# Altium schematic sheet Reference_Design_STM32WL_4L_IPD_ST_HP.SchDoc(Reference_Design_STM32WL_4L_IPD_ST_HP)
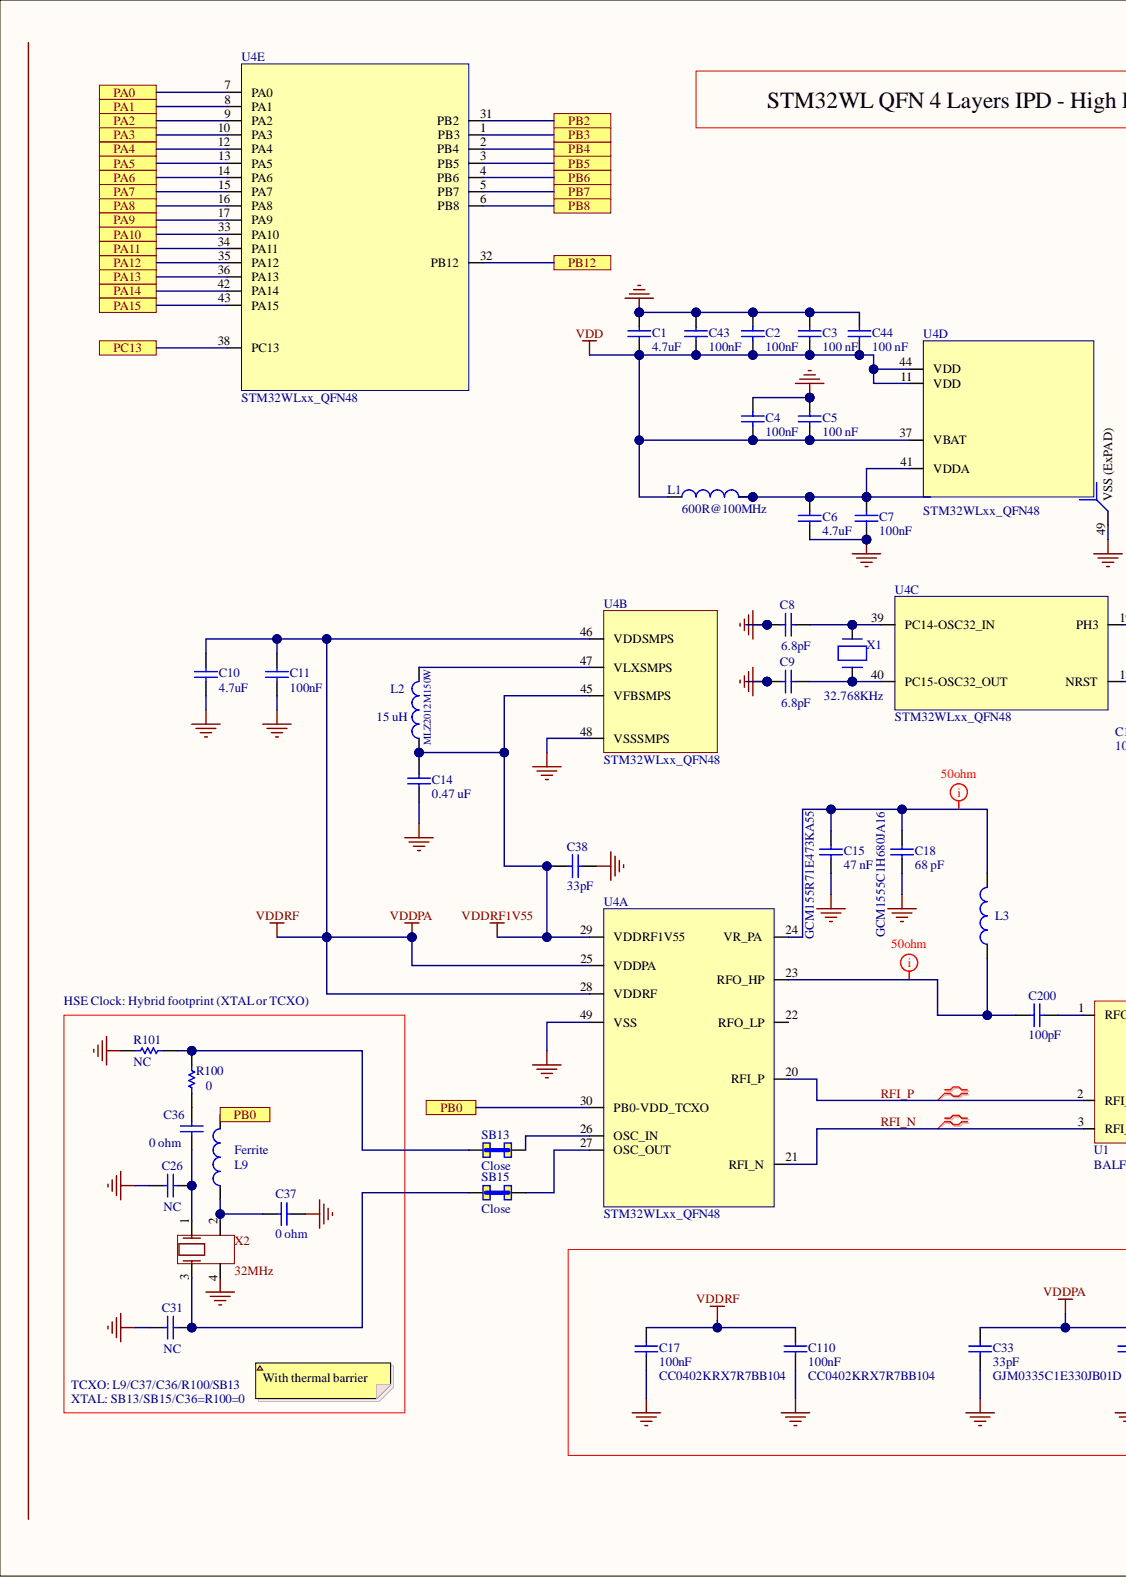
[diagram p0: Reference_Design_STM32WL_4L_IPD_ST_HP.SchDoc, left]
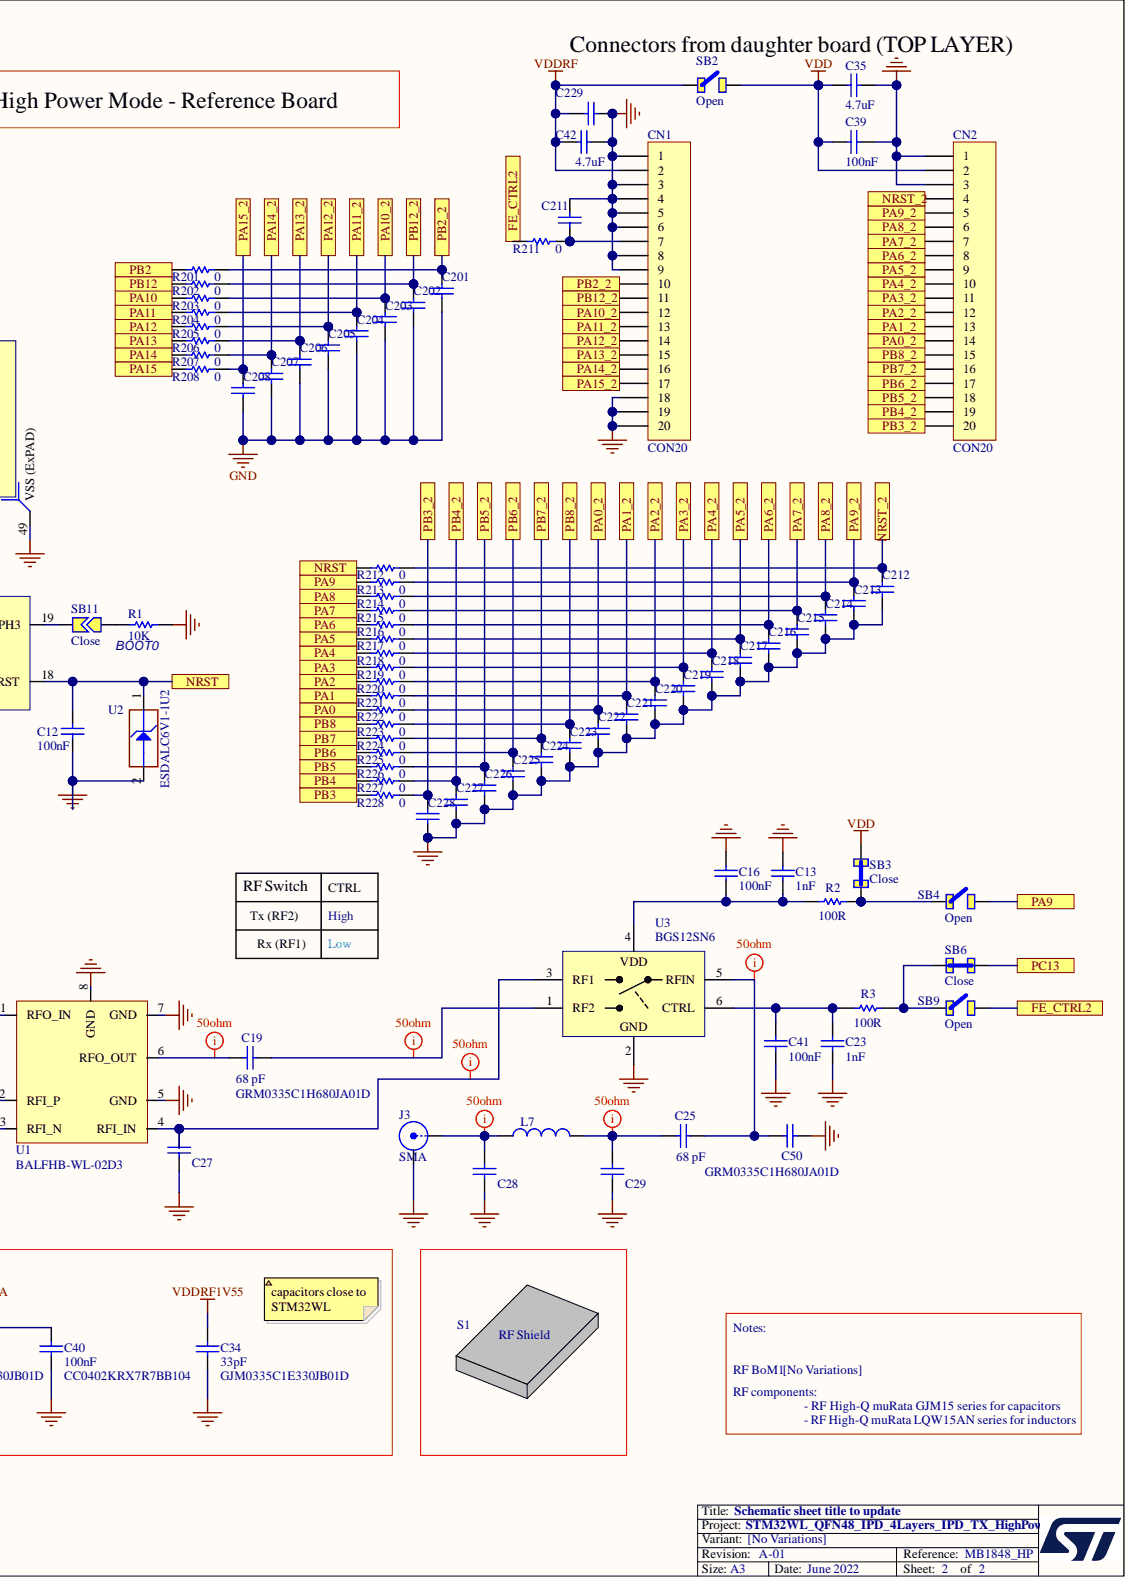
[diagram p1: Reference_Design_STM32WL_4L_IPD_ST_HP.SchDoc, right]

## Parts
C1  value 4.7uF  [CAPC1608X09N]
C2  value 100nF  [CAPC1005X06N]
C3  value 100 nF  [0201]
C4  value 100nF  [CAPC1005X06N]
C5  value 100 nF  [0201]
C6  value 4.7uF  [CAPC1608X09N]
C7  value 100nF  [CAPC1005X06N]
C8  value 6.8pF  [0402]  (hidden comment: Capacitor)
C9  value 6.8pF  [0402]  (hidden comment: Capacitor)
C10  value 4.7uF  [CAPC1608X09N]
C11  value 100nF  [CAPC1005X06N]
C12  value 100nF  [0402]
C13  value 1nF  [0201]  (hidden comment: MCD-00198)
C14  value 0.47 uF  [CAPC1608X09N]
C15  value 47 nF  Manufacturer_Part_Number: GCM155R71E473KA55  [0402]
C16  value 100nF  [0201]  (hidden comment: MCD-00102)
C17  value 100nF  Manufacturer_Part_Number: CC0402KRX7R7BB104  [CAPC1005X06N]
C18  value 68 pF  Manufacturer_Part_Number: GCM1555C1H680JA16  [0402]
C19  value 68 pF  Manufacturer_Part_Number: GRM0335C1H680JA01D  [rlc_201_smd]  (hidden comment: RFCapacitor_201)
C23  value 1nF  [0201]  (hidden comment: MCD-00198)
C25  value 68 pF  [rlc_201_smd]  (hidden comment: RFCapacitor_201)
C26  value NC  [0402]  (hidden comment: Capacitor)
C27  NC  [0201]  (value not drawn)
C28  RFCapacitor_201  [rlc_201_smd]  (value not drawn)
C29  RFCapacitor_201  [rlc_201_smd]  (value not drawn)
C31  value NC  [0402]  (hidden comment: Capacitor)
C33  value 33pF  Manufacturer_Part_Number: GJM0335C1E330JB01D  [0201]
C34  value 33pF  Manufacturer_Part_Number: GJM0335C1E330JB01D  [0201]
C35  value 4.7uF  [CAPC1608X09N]
C36  value 0 ohm  [0402]
C37  value 0 ohm  [0402]
C38  value 33pF  [0201]
C39  value 100nF  [CAPC1005X06N]
C40  value 100nF  Manufacturer_Part_Number: CC0402KRX7R7BB104  [CAPC1005X06N]
C41  value 100nF  [0201]  (hidden comment: MCD-00102)
C42  value 4.7uF  [CAPC1608X09N]
C43  value 100nF  [CAPC1005X06N]
C44  value 100 nF  [0201]
C50  RFCapacitor_201  [rlc_201_smd]  (value not drawn)
C110  value 100nF  Manufacturer_Part_Number: CC0402KRX7R7BB104  [CAPC1005X06N]
C200  value 100pF  [0201]  (hidden comment: MCD-00198)
C201  RFCapacitor_201  [rlc_201_smd]  (value not drawn)
C202  RFCapacitor_201  [rlc_201_smd]  (value not drawn)
C203  RFCapacitor_201  [rlc_201_smd]  (value not drawn)
C204  RFCapacitor_201  [rlc_201_smd]  (value not drawn)
C205  RFCapacitor_201  [rlc_201_smd]  (value not drawn)
C206  RFCapacitor_201  [rlc_201_smd]  (value not drawn)
C207  RFCapacitor_201  [rlc_201_smd]  (value not drawn)
C208  RFCapacitor_201  [rlc_201_smd]  (value not drawn)
C211  RFCapacitor_201  [rlc_201_smd]  (value not drawn)
C212  RFCapacitor_201  [rlc_201_smd]  (value not drawn)
C213  RFCapacitor_201  [rlc_201_smd]  (value not drawn)
C214  RFCapacitor_201  [rlc_201_smd]  (value not drawn)
C215  RFCapacitor_201  [rlc_201_smd]  (value not drawn)
C216  RFCapacitor_201  [rlc_201_smd]  (value not drawn)
C217  RFCapacitor_201  [rlc_201_smd]  (value not drawn)
C218  RFCapacitor_201  [rlc_201_smd]  (value not drawn)
C219  RFCapacitor_201  [rlc_201_smd]  (value not drawn)
C220  RFCapacitor_201  [rlc_201_smd]  (value not drawn)
C221  RFCapacitor_201  [rlc_201_smd]  (value not drawn)
C222  RFCapacitor_201  [rlc_201_smd]  (value not drawn)
C223  RFCapacitor_201  [rlc_201_smd]  (value not drawn)
C224  RFCapacitor_201  [rlc_201_smd]  (value not drawn)
C225  RFCapacitor_201  [rlc_201_smd]  (value not drawn)
C226  RFCapacitor_201  [rlc_201_smd]  (value not drawn)
C227  RFCapacitor_201  [rlc_201_smd]  (value not drawn)
C228  RFCapacitor_201  [rlc_201_smd]  (value not drawn)
C229  RFCapacitor_201  [rlc_201_smd]  (value not drawn)
CN1  CON20  [CO_SAMTEC_TSW-120-07-x-S]
CN2  CON20  [CO_SAMTEC_TSW-120-07-x-S]
J3  SMA  [SMA_AMPHENOL_50ohms_Edge_PCB]
L1  value 600R@100MHz  [INDC1608X95N]
L2  value 15 uH  Manufacturer_Part_Number: MLZ2012M150W  [INDC2012X13N]
L3  MCD-01330  [IND_MURATA_LQW15A]  (value not drawn)
L7  Ferrite  [rlc_201_smd]  (value not drawn)
L9  Ferrite  [IND_MURATA_LQW15A]
R1  value 10K  [RESC1608X05N]
R2  value 100R  [0201]  (hidden comment: MCD-00119)
R3  value 100R  [0201]  (hidden comment: MCD-00119)
R100  value 0  [R0402]  (hidden comment: Resistor)
R101  value NC  [R0402]  (hidden comment: Resistor)
R201  value 0  [0201]  (hidden comment: MCD-00119)
R202  value 0  [0201]  (hidden comment: MCD-00119)
R203  value 0  [0201]  (hidden comment: MCD-00119)
R204  value 0  [0201]  (hidden comment: MCD-00119)
R205  value 0  [0201]  (hidden comment: MCD-00119)
R206  value 0  [0201]  (hidden comment: MCD-00119)
R207  value 0  [0201]  (hidden comment: MCD-00119)
R208  value 0  [0201]  (hidden comment: MCD-00119)
R211  value 0  [0201]  (hidden comment: MCD-00119)
R212  value 0  [0201]  (hidden comment: MCD-00119)
R213  value 0  [0201]  (hidden comment: MCD-00119)
R214  value 0  [0201]  (hidden comment: MCD-00119)
R215  value 0  [0201]  (hidden comment: MCD-00119)
R216  value 0  [0201]  (hidden comment: MCD-00119)
R217  value 0  [0201]  (hidden comment: MCD-00119)
R218  value 0  [0201]  (hidden comment: MCD-00119)
R219  value 0  [0201]  (hidden comment: MCD-00119)
R220  value 0  [0201]  (hidden comment: MCD-00119)
R221  value 0  [0201]  (hidden comment: MCD-00119)
R222  value 0  [0201]  (hidden comment: MCD-00119)
R223  value 0  [0201]  (hidden comment: MCD-00119)
R224  value 0  [0201]  (hidden comment: MCD-00119)
R225  value 0  [0201]  (hidden comment: MCD-00119)
R226  value 0  [0201]  (hidden comment: MCD-00119)
R227  value 0  [0201]  (hidden comment: MCD-00119)
R228  value 0  [0201]  (hidden comment: MCD-00119)
RF_BoM1  RF-BOM  (value not drawn)  (mechanical)
S1  RF-Shield  (mechanical)
SB2  Open  [SB_0402]
SB3  Close  [0201]
SB4  Open  [0201]
SB6  Close  [0201]
SB9  Open  [0201]
SB11  Close  [GC_0402]
SB13  Close  [SB_0402]
SB15  Close  [SB_0402]
U1  BALFHB-WL-02D3  [IPD STM]
U2  Manufacturer_Part_Number: ESDALC6V1-1U2  [DIOM0603X03N]
U3  BGS12SN6  [TSNP-6-2]
U4  STM32WLxx_QFN48  [STM32WLxx_QFN48]  (parts A,B,C,D,E)
X1  value 32.768KHz  [OSC_NDK_NX2012SA]  (hidden comment: XTAL_2_PINS)
X2  value 32MHz  [OSC_NDK_NX2016SA]

## Ports
FE_CTRL2, NRST, NRST_2, PA0, PA0_2, PA1, PA1_2, PA2, PA2_2, PA3, PA3_2, PA4, PA4_2, PA5, PA5_2, PA6, PA6_2, PA7, PA7_2, PA8, PA8_2, PA9, PA9_2, PA10, PA10_2, PA11, PA11_2, PA12, PA12_2, PA13, PA13_2, PA14, PA14_2, PA15, PA15_2, PB0, PB2, PB2_2, PB3, PB3_2, PB4, PB4_2, PB5, PB5_2, PB6, PB6_2, PB7, PB7_2, PB8, PB8_2, PB12, PB12_2, PC13

## Nets
GND: C1.1 C2.1 C3.1 C4.1 C5.1 C6.2 C7.2 C8.2 C9.2 C10.2 C11.2 C12.2 C13.1 C14.2 C15.2 C16.1 C17.2 C18.1 C23.1 C26.2 C27.1 C28.1 C29.1 C31.2 C33.1 C34.1 C35.1 C37.1 C38.2 C39.2 C40.2 C41.2 C42.2 C43.1 C44.1 C50.1 C110.2 C201.2 C202.2 C203.2 C204.2 C205.2 C206.2 C207.2 C208.2 C211.1 C212.2 C213.2 C214.2 C215.2 C216.2 C217.2 C218.2 C219.2 C220.2 C221.2 C222.2 C223.2 C224.2 C225.2 C226.2 C227.2 C228.2 C229.2 CN1.1 CN1.3 CN1.4 CN1.5 CN1.6 CN1.8 CN1.9 CN1.18 CN1.19 CN1.20 CN2.1 CN2.3 J3.2 R1.1 R101.2 U1.5(GND) U1.7(GND) U1.8(GND) U2.2 U3.2 U4.48(VSSSMPS) U4.49(VSS) X2.4
VDD: C1.2 C2.2 C3.2 C4.2 C5.2 C35.2 C39.1 C43.2 C44.2 CN2.2 L1.1 SB2.1 SB3.1 U4.11(VDD) U4.37(VBAT) U4.44(VDD)
VDDPA: C10.1 C11.1 C17.1 C33.2 C40.1 C42.1 C110.1 C229.1 CN1.2 SB2.2 U4.25(VDDPA) U4.28(VDDRF) U4.46(VDDSMPS)
VDDRF1V55: C14.1 C34.2 C38.1 L2.1 U4.29(VDDRF1V55) U4.45(VFBSMPS)
FE_CTRL2: R211.1 SB9.1 ; port FE_CTRL2
NRST: C12.1 R212.1 U2.1 U4.18(NRST) ; port NRST
NRST_2: C212.1 CN2.4 R212.2 ; port NRST_2
PA0: R222.1 U4.7(PA0) ; port PA0
PA0_2: C222.1 CN2.14 R222.2 ; port PA0_2
PA1: R221.1 U4.8(PA1) ; port PA1
PA1_2: C221.1 CN2.13 R221.2 ; port PA1_2
PA2: R220.1 U4.9(PA2) ; port PA2
PA2_2: C220.1 CN2.12 R220.2 ; port PA2_2
PA3: R219.1 U4.10(PA3) ; port PA3
PA3_2: C219.1 CN2.11 R219.2 ; port PA3_2
PA4: R218.1 U4.12(PA4) ; port PA4
PA4_2: C218.1 CN2.10 R218.2 ; port PA4_2
PA5: R217.1 U4.13(PA5) ; port PA5
PA5_2: C217.1 CN2.9 R217.2 ; port PA5_2
PA6: R216.1 U4.14(PA6) ; port PA6
PA6_2: C216.1 CN2.8 R216.2 ; port PA6_2
PA7: R215.1 U4.15(PA7) ; port PA7
PA7_2: C215.1 CN2.7 R215.2 ; port PA7_2
PA8: R214.1 U4.16(PA8) ; port PA8
PA8_2: C214.1 CN2.6 R214.2 ; port PA8_2
PA9: R213.1 SB4.1 U4.17(PA9) ; port PA9
PA9_2: C213.1 CN2.5 R213.2 ; port PA9_2
PA10: R203.1 U4.33(PA10) ; port PA10
PA10_2: C203.1 CN1.12 R203.2 ; port PA10_2
PA11: R204.1 U4.34(PA11) ; port PA11
PA11_2: C204.1 CN1.13 R204.2 ; port PA11_2
PA12: R205.1 U4.35(PA12) ; port PA12
PA12_2: C205.1 CN1.14 R205.2 ; port PA12_2
PA13: R206.1 U4.36(PA13) ; port PA13
PA13_2: C206.1 CN1.15 R206.2 ; port PA13_2
PA14: R207.1 U4.42(PA14) ; port PA14
PA14_2: C207.1 CN1.16 R207.2 ; port PA14_2
PA15: R208.1 U4.43(PA15) ; port PA15
PA15_2: C208.1 CN1.17 R208.2 ; port PA15_2
PB0: L9.2 U4.30(PB0-VDD_TCXO) ; port PB0
PB2: R201.1 U4.31(PB2) ; port PB2
PB2_2: C201.1 CN1.10 R201.2 ; port PB2_2
PB3: R228.1 U4.1(PB3) ; port PB3
PB3_2: C228.1 CN2.20 R228.2 ; port PB3_2
PB4: R227.1 U4.2(PB4) ; port PB4
PB4_2: C227.1 CN2.19 R227.2 ; port PB4_2
PB5: R226.1 U4.3(PB5) ; port PB5
PB5_2: C226.1 CN2.18 R226.2 ; port PB5_2
PB6: R225.1 U4.4(PB6) ; port PB6
PB6_2: C225.1 CN2.17 R225.2 ; port PB6_2
PB7: R224.1 U4.5(PB7) ; port PB7
PB7_2: C224.1 CN2.16 R224.2 ; port PB7_2
PB8: R223.1 U4.6(PB8) ; port PB8
PB8_2: C223.1 CN2.15 R223.2 ; port PB8_2
PB12: R202.1 U4.32(PB12) ; port PB12
PB12_2: C202.1 CN1.11 R202.2 ; port PB12_2
PC13: SB6.1 U4.38(PC13) ; port PC13
RFI_N: U1.3(RFI_N) U4.21(RFI_N)
RFI_P: U1.2(RFI_P) U4.20(RFI_P)
NetC6_1: C6.1 C7.1 L1.2 U4.41(VDDA)
NetC8_1: C8.1 U4.39(PC14-OSC32_IN) X1.2
NetC9_1: C9.1 U4.40(PC15-OSC32_OUT) X1.1
NetC13_2: C13.2 C16.2 R2.1 U3.4
NetC15_1: C15.1 C18.2 L3.1 U4.24(VR_PA)
NetC19_1: C19.1 U3.1(RF2)
NetC19_2: C19.2 U1.6(RFO_OUT)
NetC23_2: C23.2 C41.1 R3.1 U3.6(CTRL)
NetC25_1: C25.1 C50.2 U3.5(RFIN)
NetC25_2: C25.2 C29.2 L7.1
NetC26_1: C26.1 C36.2 X2.1
NetC27_2: C27.2 U1.4(RFI_IN) U3.3(RF1)
NetC28_2: C28.2 J3.1 L7.2
NetC31_1: C31.1 SB15.2 X2.3
NetC36_1: C36.1 R100.2
NetC37_2: C37.2 L9.1 X2.2
NetC200_1: C200.1 U1.1(RFO_IN)
NetC200_2: C200.2 L3.2 U4.23(RFO_HP)
NetC211_2: C211.2 CN1.7 R211.2
NetL2_2: L2.2 U4.47(VLXSMPS)
NetR1_2: R1.2 SB11.1
NetR2_2: R2.2 SB3.2 SB4.2
NetR3_2: R3.2 SB6.2 SB9.2
NetR100_1: R100.1 R101.1 SB13.2
NetSB11_2: SB11.2 U4.19(PH3)
NetSB13_1: SB13.1 U4.26(OSC_IN)
NetSB15_1: SB15.1 U4.27(OSC_OUT)

Not connected: U4 22(RFO_LP)

## Library data of the parts
C1 C6 C10 C35 C42 = MCD-00009: Capacitor, MLCC, 4.7uF, 16V, X5R, 10%, 0603, T=0.8mm; Manufacturer: YAGEO; Manufacturer_Part_Number: CC0603KRX5R7BB475; Component Kind: Standard; Library Name: MB1848.SCHLIB; Package: C0603
C2 C4 C7 C11 C12 C39 C43 = MCD-00102: Capacitor, MLCC, 100nF, 16V, X7R, 10%, 0402, T=0,5mm; Manufacturer: YAGEO; Manufacturer_Part_Number: CC0402KRX7R7BB104; Component Kind: Standard; Library Name: MB1848.SCHLIB; Package: C0402
C3 = MCD-00102: Multilayer Ceramic Capacitors MLCC - SMD/SMT 0201 25VDC 0.1uF 10% X5R 0.3mm; Manufacturer: TDK; Manufacturer_Part_Number: C0603X5R1E104K030BB; Value: 100 nF; Component Kind: Standard; Library Name: MB1848.SCHLIB
C5 C44 = MCD-00102: Multilayer Ceramic Capacitors MLCC - SMD/SMT 0201 25VDC 0.1uF 10% X5R 0.3mm; Manufacturer: TDK; Manufacturer_Part_Number: C0603X5R1E104K030BB; Component Kind: Standard; Library Name: MB1848.SCHLIB
C8 C9 = MCD-00003: Capacitor, MLCC, 6.8pF, 50V, NPO, +-0.1pF, 0402, T=0.5mm; Manufacturer: MURATA; Manufacturer_Part_Number: GRM1555C1H6R8BA01; Component Kind: Standard; Library Name: MB1848.SCHLIB
  C8: Value: 6.8pF
C13 C23 = MCD-00198: Multilayer ceramic capacitors X7R ±10%, 50V; Manufacturer: MURATA; Manufacturer_Part_Number: GRM033R71H102KA12D; Component Kind: Standard; Library Name: MB1848.SCHLIB
C14 = MCD-00009: Capacitor, MLCC, 4.7 uF, 16V, X5R, 10%, 0603, T=0.8mm; Manufacturer: YAGEO; Manufacturer_Part_Number: CC0603KRX5R7BB474; Component Kind: Standard; Library Name: MB1848.SCHLIB; Package: C0603
C15 = MCD-01123: Capacitor, MLCC,47nF, 16V, X7R, 10%, 0402, T=0.5mm; Manufacturer: MURATA; Component Kind: Standard; Library Name: MB1848.SCHLIB
C16 C41 = MCD-00102: Multilayer ceramic capacitors X5R ±10%, 10V; Manufacturer: MURATA; Manufacturer_Part_Number: GRM033R61A104KE15D; Component Kind: Standard; Library Name: MB1848.SCHLIB; Package: C0201
C17 C40 C110 = MCD-00102: Capacitor, MLCC, 100nF, 16V, X7R, 10%, 0402, T=0,5mm; Manufacturer: YAGEO; Component Kind: Standard; Library Name: MB1848.SCHLIB; Package: C0402
C18 = MCD-01123: Capacitor, MLCC,68pF, 50V, C0G, 1%, 0402, T=0.5mm; Manufacturer: MURATA; Component Kind: Standard; Library Name: MB1848.SCHLIB
C19 = RFCapacitor_201: Multilayer ceramic capacitors COG ±5%, 50V; Manufacturer: MURATA; Component Kind: Standard; Library Name: MB1848.SCHLIB
C25 = RFCapacitor_201: Multilayer ceramic capacitors COG ±5%, 50V; Manufacturer: MURATA; Manufacturer_Part_Number: GRM0335C1H680JA01D; Component Kind: Standard; Library Name: MB1848.SCHLIB
C26 C31 = MCD-00003: Not connected; Component Kind: Standard; Library Name: MB1848.SCHLIB; Manufacturer_Part_Number: Not connected
C27 = RFCapacitor_201: RF Capacitor High-Q, 0201; Component Kind: Standard; Library Name: MB1848.SCHLIB; Value: NC
C28 C29 C50 C201-C208 C211-C229 = RFCapacitor_201: RF Capacitor High-Q, 0201; Value: NC; Component Kind: Standard; Library Name: MB1848.SCHLIB
C33 C34 = MCD-00277: Multilayer Ceramic Capacitors MLCC - SMD/SMT 0201 33pF 25volts C0G 5%; Manufacturer: MURATA; Component Kind: Standard; Library Name: MB1848.SCHLIB
C36 = MCD-00277: Capacitor, MLCC, 33pF, 50V, NPO, 2%, 0402, T=0,5mm; Manufacturer: Vishay / Dale; Manufacturer_Part_Number: RCS04020000Z0ED; Value: 0 ohm; Component Kind: Standard; Library Name: MB1848.SCHLIB
C37 = MCD-00277: Capacitor, MLCC, 33pF, 50V, NPO, 2%, 0402, T=0,5mm; Manufacturer: Vishay / Dale; Manufacturer_Part_Number: RCS04020000Z0ED; Component Kind: Standard; Library Name: MB1848.SCHLIB
C38 = MCD-01123: Multilayer Ceramic Capacitors MLCC - SMD/SMT 0201 33pF 25volts C0G 5%; Manufacturer: MURATA; Manufacturer_Part_Number: GJM0335C1E330JB01D; Component Kind: Standard; Library Name: MB1848.SCHLIB
C200 = MCD-00198: Multilayer ceramic capacitors COG +/-5%, 50V; Manufacturer: MURATA; Manufacturer_Part_Number: GRM033R71H102KA12D; Component Kind: Standard; Library Name: MB1849.SCHLIB
CN1 CN2 = CON20: Connector right-angle, pitch 2.54mm, 20 contacts; Manufacturer: SAMTEC; Manufacturer_Part_Number: TSW-120-07-L-S; Component Kind: Standard; Library Name: MB1848.SCHLIB
J3 = SMA: SMA Straight Connector; Manufacturer: Johnson / Cinch Connectivity Solutions; Manufacturer_Part_Number: 142-0701-841; Component Kind: Standard; Library Name: MB1848.SCHLIB
L1 = MCD-00047: SMD Chip ferrite bead, 600R@100MHz +/-25%, 350mA, 500mohm DC, 0603, T=0.8mm; Manufacturer: Taiyo Yuden; Manufacturer_Part_Number: BK1608HS601-T; Component Kind: Standard; Library Name: MB1848.SCHLIB
L2 = MCD-01433: MLZ2012 Multilayer Shielded Inductor 15 uH, ±5%; Manufacturer: TDK; Component Kind: Standard; Library Name: MB1848.SCHLIB
L3 = MCD-01330: Inductor, 47nH, +-0.1nH, 140mA, 0402; Value: NC; Component Kind: Standard; Library Name: MB1848.SCHLIB
L7 = RFInductor_201: Thick Film Resistor ±5%, 1/20W; Manufacturer: Vishay/Dale; Manufacturer_Part_Number: CRCW02010000Z0ED; Value: 0R; Component Kind: Standard; ComponentLink1Description: Datasheet; Library Name: MB1848.SCHLIB; Package: L0201; Part_Type: Inductor; Status: Footprint available
L9 = MCD-01330: Not connected; Manufacturer_Part_Number: NC; Part_Type: Ferrite bead; Initial_Board_Reference: MB1402; Provided_By: SUBCO; Value: NC; Component Kind: Standard; ComponentLink1Description: Datasheet; ComponentLink1URL: http://tunmcdsvn.tun.st.com/Component_Datasheet_Library/Trunk/Inductors/Murata_inductor_LQW15AN3N9B00/; Library Name: MB1848.SCHLIB; Package: L0402
R1 = MCD-00220: Resistor,10K,0603,1%,1/10W; Manufacturer: YAGEO; Manufacturer_Part_Number: RC0603FR-0710KL; Component Kind: Standard; Library Name: MB1848.SCHLIB
R2 R3 R201-R208 R211-R228 = MCD-00119: Thick Film Resistors - SMD 1/20watt 100ohms 5% 200ppm; Manufacturer: Vishay / Dale; Manufacturer_Part_Number: CRCW0201100RJNED; Component Kind: Standard; Library Name: MB1848.SCHLIB
R100 = MCD-00052: Resistor,0R,0402,5%,1/16W; Manufacturer: YAGEO; Manufacturer_Part_Number: RC0402JR-070RL; Initial_Board_Reference: MB1274; Provided_By: SUBCO; Component Kind: Standard; ComponentLink1Description: Datasheet; ComponentLink1URL: http://tunmcdsvn.tun.st.com/Component_Datasheet_Library/Trunk/Resistors/Yageo_Resistor_RC0402-series; Internal_Part_Number: MCD-00052; Library Name: MB1848.SCHLIB; Package: R0402; Part_Type: Resistor; Status: Released
R101 = MCD-00052: Not connected; Manufacturer: YAGEO; Initial_Board_Reference: MB1274; Provided_By: SUBCO; Component Kind: Standard; ComponentLink1Description: Datasheet; ComponentLink1URL: http://tunmcdsvn.tun.st.com/Component_Datasheet_Library/Trunk/Resistors/Yageo_Resistor_RC0402-series; Internal_Part_Number: MCD-00052; Library Name: MB1848.SCHLIB; Manufacturer_Part_Number: Not connected; Package: R0402; Part_Type: Resistor; Status: Released
S1 = RF-Shield: RF Shield Frame + Cover without apertures; Manufacturer: Masach Tech; Manufacturer_Part_Number: MS409-10; Library Name: MB1848.SCHLIB
SB2 SB4 SB9 = SB_Open: Solder Bridge Open [0402] (Unpopulated); Component Kind: Standard; Library Name: MB1848.SCHLIB; Revision: July-2002: Re-released for DXP Platform.; Signal Integrity: Guessed Model
SB3 SB6 = SB_0Ohm: Thick Film Resistors - SMD 1/20watt ZEROohm Jumper; Manufacturer: Vishay / Dale; Manufacturer_Part_Number: CRCW02010000Z0ED; VALUE: 0; Component Kind: Standard; Library Name: MB1848.SCHLIB; Revision: July-2002: Re-released for DXP Platform.; Signal Integrity: Guessed Model
SB11 = Strap2: Solder Jumper - Close; Manufacturer: Vishay / Dale; Manufacturer_Part_Number: RCS04020000Z0ED; Component Kind: Standard; Library Name: MB1848.SCHLIB; Revision: July-2002: Re-released for DXP Platform.
SB13 SB15 = SB_0Ohm: Solder Bridge 0R [0402] (Populated with 0 Ohm); Manufacturer: Vishay / Dale; Manufacturer_Part_Number: RCS04020000Z0ED; VALUE: 0; Component Kind: Standard; Library Name: MB1848.SCHLIB; Revision: July-2002: Re-released for DXP Platform.; Signal Integrity: Guessed Model
U1 = IPD ST STM32WL: IPD STM STM32WL; Manufacturer: STMICROELECTRONICS; Component Kind: Standard; Library Name: MB1848.SCHLIB; Manufacturer_Part_Number: BALFHB-WL-02D3
U2 = MCD-00170: ESD protection 6V1; Manufacturer: STMICROELECTRONICS; Component Kind: Standard; Library Name: MB1848.SCHLIB
U3 = BGS12SN6: DC switch - Ultra-Low  SPDT analog switch; Manufacturer: INFINEON; Component Kind: Standard; Library Name: MB1848.SCHLIB; Manufacturer_Part_Number: BGS12SN6
U4 = STM32WLxx_QFN48: STM32WL ARM MCU Multiprotocol LPWAN 32-bit Arm Cortex-M4 MCUs LoRa (G)FSK-MSK BPSK; Manufacturer: STMicroelectronics; Manufacturer_Part_Number: STM32WLE5CC; Component Kind: Standard; Library Name: MB1848.SCHLIB
X1 = MCD-01479: Crystal 32.768kHz, 6pF, -+20PPM, R=80K, SMD; Manufacturer: NDK; Manufacturer_Part_Number: NX2012SA 32.768KHz EXS00A-MU00527; Component Kind: Standard; Library Name: MB1848.SCHLIB; Value: 32.768KHz
X2 = MCD-01446: Crystal 32 MHz, 8pF, -+10 PPM, R=60Ω, SMD; Manufacturer: NDK; Manufacturer_Part_Number: NX2016SA 32MHz EXS00A-CS06465; Component Kind: Standard; Library Name: MB1848.SCHLIB; Signal Integrity: Guessed Model
RF_BoM1 = RF-BOM: Library Name: MB1848.SCHLIB
